# Altium schematic sheet Board to Board Conn.SchDoc
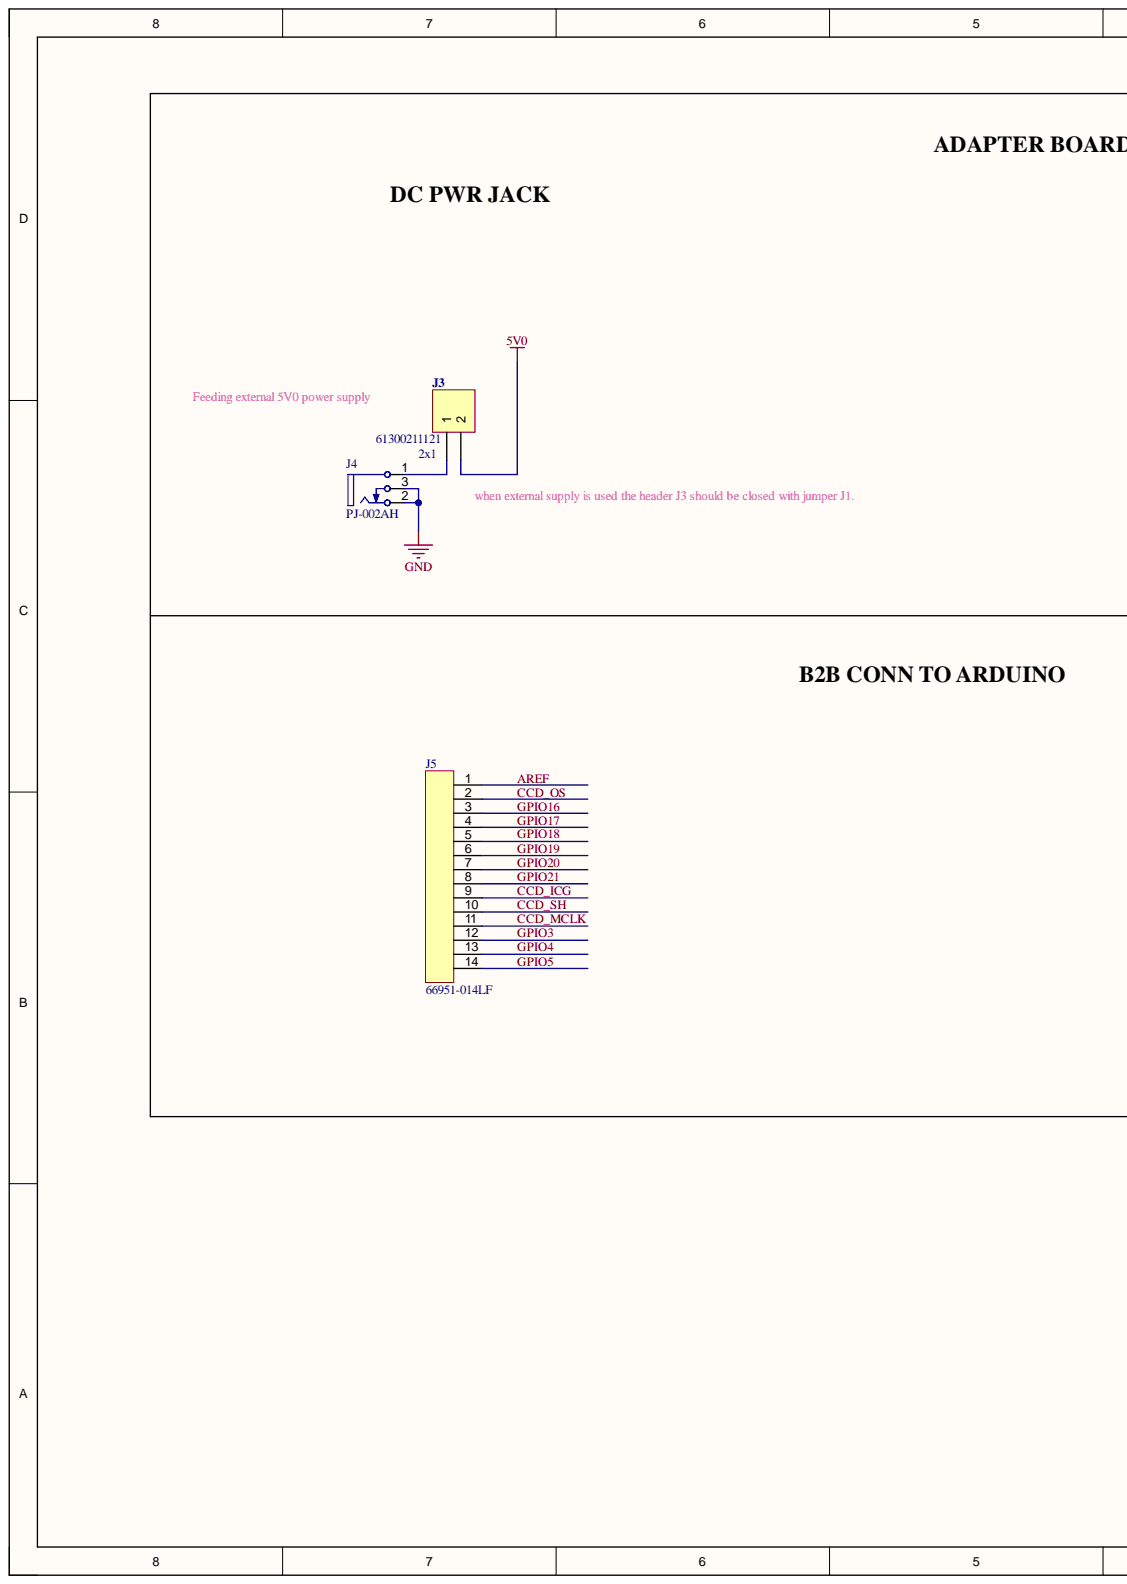
[diagram p0: Board to Board Conn.SchDoc, left]
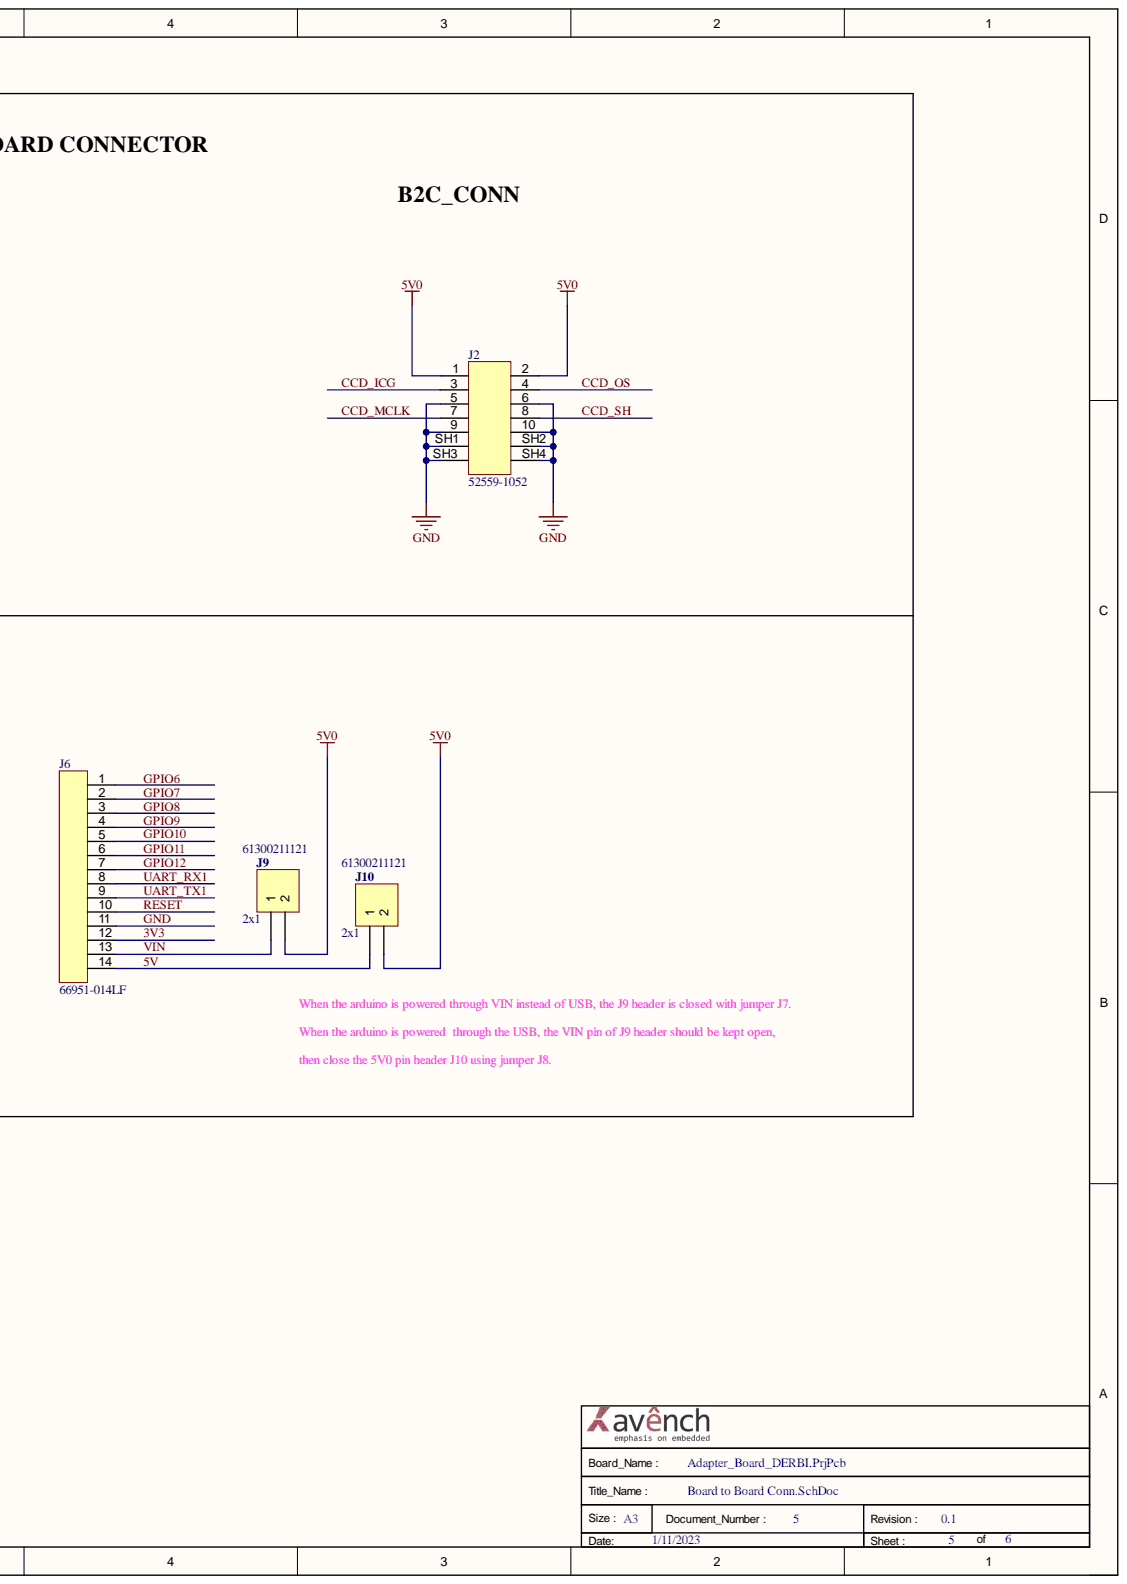
[diagram p1: Board to Board Conn.SchDoc, right]

## Parts
J2  value 52559-1052  MPN: 52559-1052  [CONN_10Pos_  52559-1052]  (hidden comment: CONN_FFC_VERT_10POS_0.50MM_SMD)
J3  value 2x1  MPN: 61300211121  [CONN2P254_61300211121]  (hidden comment: CONN_HEADER_VERT_2POS_2.54MM)
J4  MPN: PJ-002AH  [CONN_PWR_JACK_2X5.5MM]  (hidden comment: CONN_PWR_JACK_2X5.5MM_SOLDER)
J5  MPN: 66951-014LF  [AMPHENOL_66951-014LF]  (hidden comment: CONN_RCPT_14POS_0.1_GOLD_PCB)
J6  MPN: 66951-014LF  [AMPHENOL_66951-014LF]  (hidden comment: CONN_RCPT_14POS_0.1_GOLD_PCB)
J9  value 2x1  MPN: 61300211121  [CONN2P254_61300211121]  (hidden comment: CONN_HEADER_VERT_2POS_2.54MM)
J10  value 2x1  MPN: 61300211121  [CONN2P254_61300211121]  (hidden comment: CONN_HEADER_VERT_2POS_2.54MM)

## Nets
3V3: J6.12
5V: J2.1 J2.2 J3.2 J6.14 J9.2 J10.1 J10.2
AREF: J5.1
CCD_ICG: J2.3 J5.9
CCD_MCLK: J2.7 J5.11
CCD_OS: J2.4 J5.2
CCD_SH: J2.8 J5.10
GND: J2.5 J2.6 J2.9 J2.10 J2.SH1 J2.SH2 J2.SH3 J2.SH4 J4.2 J4.3 J6.11
GPIO3: J5.12
GPIO4: J5.13
GPIO5: J5.14
GPIO6: J6.1
GPIO7: J6.2
GPIO8: J6.3
GPIO9: J6.4
GPIO10: J6.5
GPIO11: J6.6
GPIO12: J6.7
GPIO16: J5.3
GPIO17: J5.4
GPIO18: J5.5
GPIO19: J5.6
GPIO20: J5.7
GPIO21: J5.8
RESET: J6.10
UART_RX1: J6.8
UART_TX1: J6.9
VIN: J6.13 J9.1
NetJ3_1: J3.1 J4.1

## Library data of the parts
J2 = CONN_FFC_VERT_10POS_0.50MM_SMD: 10 Position FFC, FPC Connector Contacts, Vertical - 1 Sided 0.020" (0.50mm) Surface Mount; Manufacturer: Molex; Component Kind: Standard; Library Name: Adapter_Board.SchLib; Operating Temperature: -40°C ~ 85°C; Type: SMD
J3 J9 J10 = CONN_HEADER_VERT_2POS_2.54MM: Connector Header Through Hole 2 position 0.100" (2.54mm); Manufacturer: Würth Elektronik; Component Kind: Standard; Library Name: Adapter_Board.SchLib; Operating Temperature: -40°C ~ 105°C; Package: Through Hole; Type: Header, Breakaway
J4 = CONN_PWR_JACK_2X5.5MM_SOLDER: Power Barrel Connector Jack 2.10mm ID (0.083"), 5.50mm OD (0.217") Through Hole, Right Angle; Manufacturer: CUI Devices; Component Kind: Standard; ComponentLink1Description: Manufacturer Link; ComponentLink1URL: http://www.cliffuk.co.uk/; Library Name: Adapter_Board.SchLib; Operating temperature: -25°C ~ 85°C
J5 J6 = CONN_RCPT_14POS_0.1_GOLD_PCB: 14 Position Receptacle Connector 0.100" (2.54mm) Through Hole Gold; Manufacturer: Amphenol ICC (FCI); Value: 66951; Component Kind: Standard; Library Name: Adapter_Board.SchLib; Operating Temperature: -65°C ~ 125°C; Type: TH
